# Altium schematic sheet Microcontroller.SchDoc
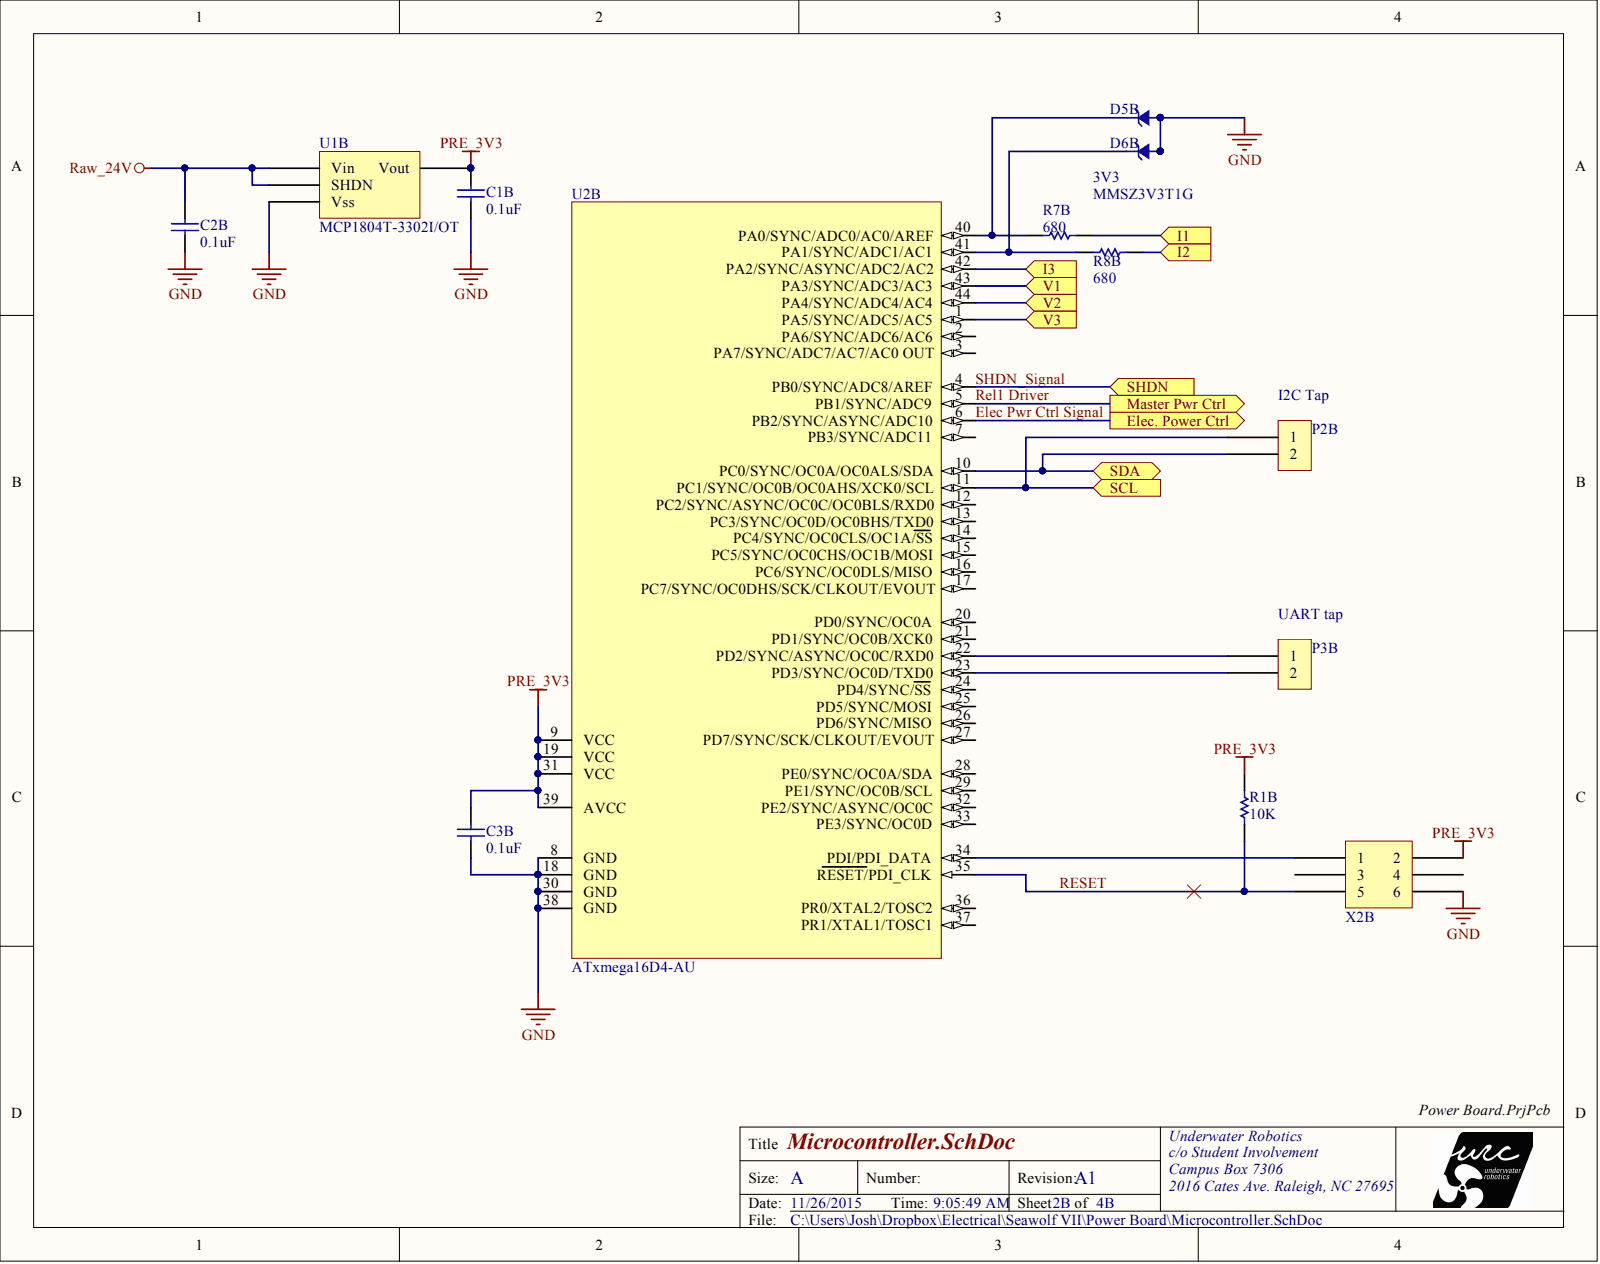
[diagram p0: Microcontroller.SchDoc]

## Parts
C1B  value 0.1uF  [C0805]  (hidden comment: Cap)
C2B  value 0.1uF  [C0805]  (hidden comment: Cap)
C3B  value 0.1uF  [C0402]  (hidden comment: Cap)
D5B  MMSZ3V3T1G, 3V3 Zener Diode  [ONSC-SOD123-2-498_A_V]  (value not drawn)
D6B  value 3V3  [ONSC-SOD123-2-498_A_V]  (hidden comment: MMSZ3V3T1G, 3V3 Zener Diode)
P2B  Header  [1x2 Header]  (value not drawn)
P3B  Header  [1x2 Header]  (value not drawn)
R1B  value 10K  [R0805]  (hidden comment: Resistor)
R7B  value 680  [R0805]  (hidden comment: Resistor)
R8B  value 680  [R0805]  (hidden comment: Resistor)
U1B  MCP1804T-3302I/OT  [MCP1804T-3302I/OT]
U2B  ATxmega16D4-AU  [44A_N]
X2B  Header  [2x3 Header]  (value not drawn)

## Ports
ELEC. POWER CTRL, I1, I2, I3, MASTER PWR CTRL, SCL, SDA, SHDN, V1, V2, V3

## Nets
GND: C1B.2 C2B.2 C3B.2 D5B.A D6B.A U1B.Vss U2B.8(GND) U2B.18(GND) U2B.30(GND) U2B.38(GND) X2B.6
PRE_3V3: C1B.1 C3B.1 R1B.2 U1B.Vout U2B.9(VCC) U2B.19(VCC) U2B.31(VCC) U2B.39(AVCC) X2B.2
RAW_24V: C2B.1 U1B.SHDN U1B.Vin
ELEC PWR CTRL SIGNAL: U2B.6(PB2/SYNC/ASYNC/ADC10) ; port ELEC. POWER CTRL
I1: R7B.1 ; port I1
I2: R8B.1 ; port I2
I3: U2B.42(PA2/SYNC/ASYNC/ADC2/AC2) ; port I3
REL1 DRIVER: U2B.5(PB1/SYNC/ADC9) ; port MASTER PWR CTRL
RESET: R1B.1 U2B.35(RESET/PDI_CLK) X2B.5
SCL: P2B.1 U2B.11(PC1/SYNC/OC0B/OC0AHS/XCK0/SCL) ; port SCL
SDA: P2B.2 U2B.10(PC0/SYNC/OC0A/OC0ALS/SDA) ; port SDA
SHDN_SIGNAL: U2B.4(PB0/SYNC/ADC8/AREF) ; port SHDN
V1: U2B.43(PA3/SYNC/ADC3/AC3) ; port V1
V2: U2B.44(PA4/SYNC/ADC4/AC4) ; port V2
V3: U2B.1(PA5/SYNC/ADC5/AC5) ; port V3
NetD5B_K: D5B.K R7B.2 U2B.40(PA0/SYNC/ADC0/AC0/AREF)
NetD6B_K: D6B.K R8B.2 U2B.41(PA1/SYNC/ADC1/AC1)
NetP3B_1: P3B.1 U2B.22(PD2/SYNC/ASYNC/OC0C/RXD0)
NetP3B_2: P3B.2 U2B.23(PD3/SYNC/OC0D/TXD0)
NetU2B_34: U2B.34(PDI/PDI_DATA) X2B.1

Not connected: U2B 2(PA6/SYNC/ADC6/AC6) 3(PA7/SYNC/ADC7/AC7/AC0_OUT) 7(PB3/SYNC/ADC11) 12(PC2/SYNC/ASYNC/OC0C/OC0BLS/RXD0) 13(PC3/SYNC/OC0D/OC0BHS/TXD0) 14(PC4/SYNC/OC0CLS/OC1A/SS) 15(PC5/SYNC/OC0CHS/OC1B/MOSI) 16(PC6/SYNC/OC0DLS/MISO) 17(PC7/SYNC/OC0DHS/SCK/CLKOUT/EVOUT) 20(PD0/SYNC/OC0A) 21(PD1/SYNC/OC0B/XCK0) 24(PD4/SYNC/SS) 25(PD5/SYNC/MOSI) 26(PD6/SYNC/MISO) 27(PD7/SYNC/SCK/CLKOUT/EVOUT) 28(PE0/SYNC/OC0A/SDA) 29(PE1/SYNC/OC0B/SCL) 32(PE2/SYNC/ASYNC/OC0C) 33(PE3/SYNC/OC0D) 36(PR0/XTAL2/TOSC2) 37(PR1/XTAL1/TOSC1); X2B 3 4

## Library data of the parts
C1B C2B C3B = Cap: Unpolarized Capacitor; Component Kind: Standard
  C1B C2B: Supplier 1: Digi-Key; Supplier Part Number 1: 311-1140-1-ND; Library Name: URC.IntLib
  C3B: Library Name: URC_Lib.IntLib
D5B D6B = MMSZ3V3T1G, 3V3 Zener Diode: 3V3 Zener Diode; Manufacturer 1: ON SEMICONDUCTOR; Description 1: ON SEMICONDUCTOR - MMSZ3V3T1G - ZENER DIODE, 500mW, 3.3V, SOD-123; Component Kind: Standard; ComponentLink1Description: http://www.farnell.com/datasheets/78656.pdf; ComponentLink1URL: http://www.farnell.com/datasheets/78656.pdf; Manufacturer Part Number: MMSZ3V3T1G; Pricing 1: 1=0.24, 50=0.156, 100=0.065, 250=0.063, 500=0.061, 1000=0.044, 3000=0.035, 9000=0.029 (USD); RoHS: YES; Stock 1: 30308; Supplier 1: Newark; Supplier Part Number 1: 71J6023; Library Name: URC.SchLib
  D5B: Value: 3V3
P2B P3B = 1x2 Header: Component Kind: Standard; Description: 1x2 Header; Library Name: URC_Lib.IntLib
R1B R7B R8B = Res: Component Kind: Standard; Description: Resistor; Library Name: URC.IntLib
U1B = MCP1804T-3302I/OT: 3.3V Linear Regulator; Component Kind: Standard; Supplier 1: Newark; Supplier Part Number 1: 55R1047; URL: http://www.newark.com/microchip/mcp1804t-3302i-ot/ldo-voltage-regulator-3-3v-150ma/dp/55R1047; Library Name: URC.SchLib
U2B = ATxmega16D4-AU: 8/16-bit AVR XMEGA D4 Microcontroller, 1.6-3.6V, 32MHz, 16KB + 4KB Flash, 1KB EEPROM, 2KB SRAM, 44-pin TQFP, Industrial Grade (-40°C to 85°C), Pb-Free; Code_JEDEC: MS-026-ACB; Component Kind: Standard; ComponentLink1Description: Manufacturer URL; ComponentLink1URL: http://www.atmel.com/; ComponentLink2Description: ATxmega128D4/64D4/32D4/16D4 Datasheet; ComponentLink2URL: http://www.atmel.com/dyn/resources/prod_documents/doc8135.pdf; DatasheetVersion: revJ, Dec-2010; Library Name: Atmel Microcontroller AVR XMEGA.IntLib; PackageDescription: 44-Pin Plastic Thin Profile Quad Flat Pack, 10 x 10 x 1.0 mm Body, 0.8 mm Pitch (TQFP); PackageReference: 44A; PackageVersion: revC, Oct-2010
X2B = 2x3 Header: Component Kind: Standard; Description: 2x3 Header; Supplier 1: Digi-Key; Supplier Part Number 1: 609-3218-ND; Library Name: URC.IntLib
